# Altium schematic sheet EWB-STM32H7B0-750VB+RGB V3.0.SchDoc
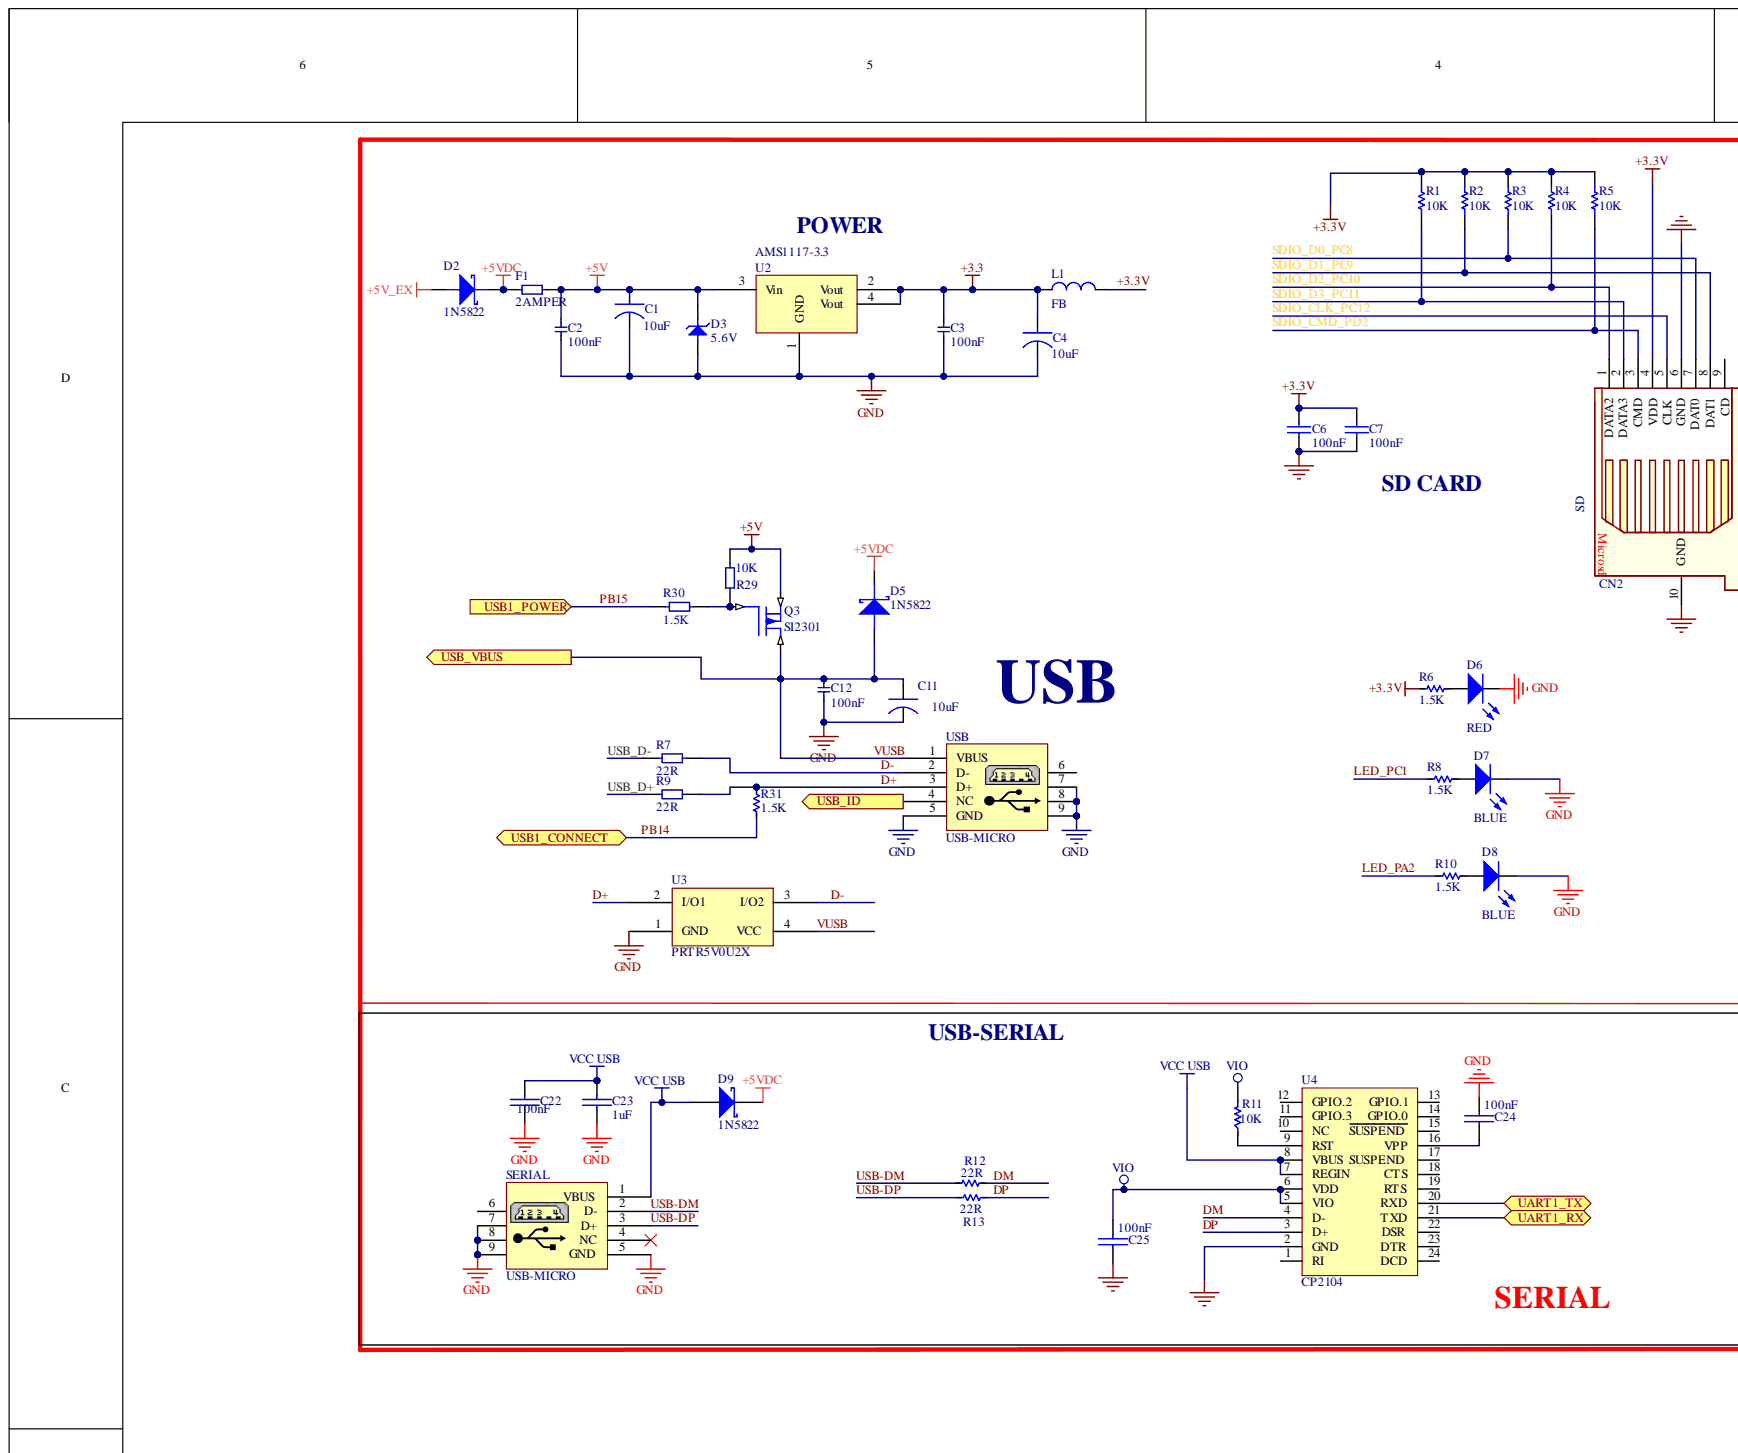
[diagram p0: EWB-STM32H7B0-750VB+RGB V3.0.SchDoc, top left]
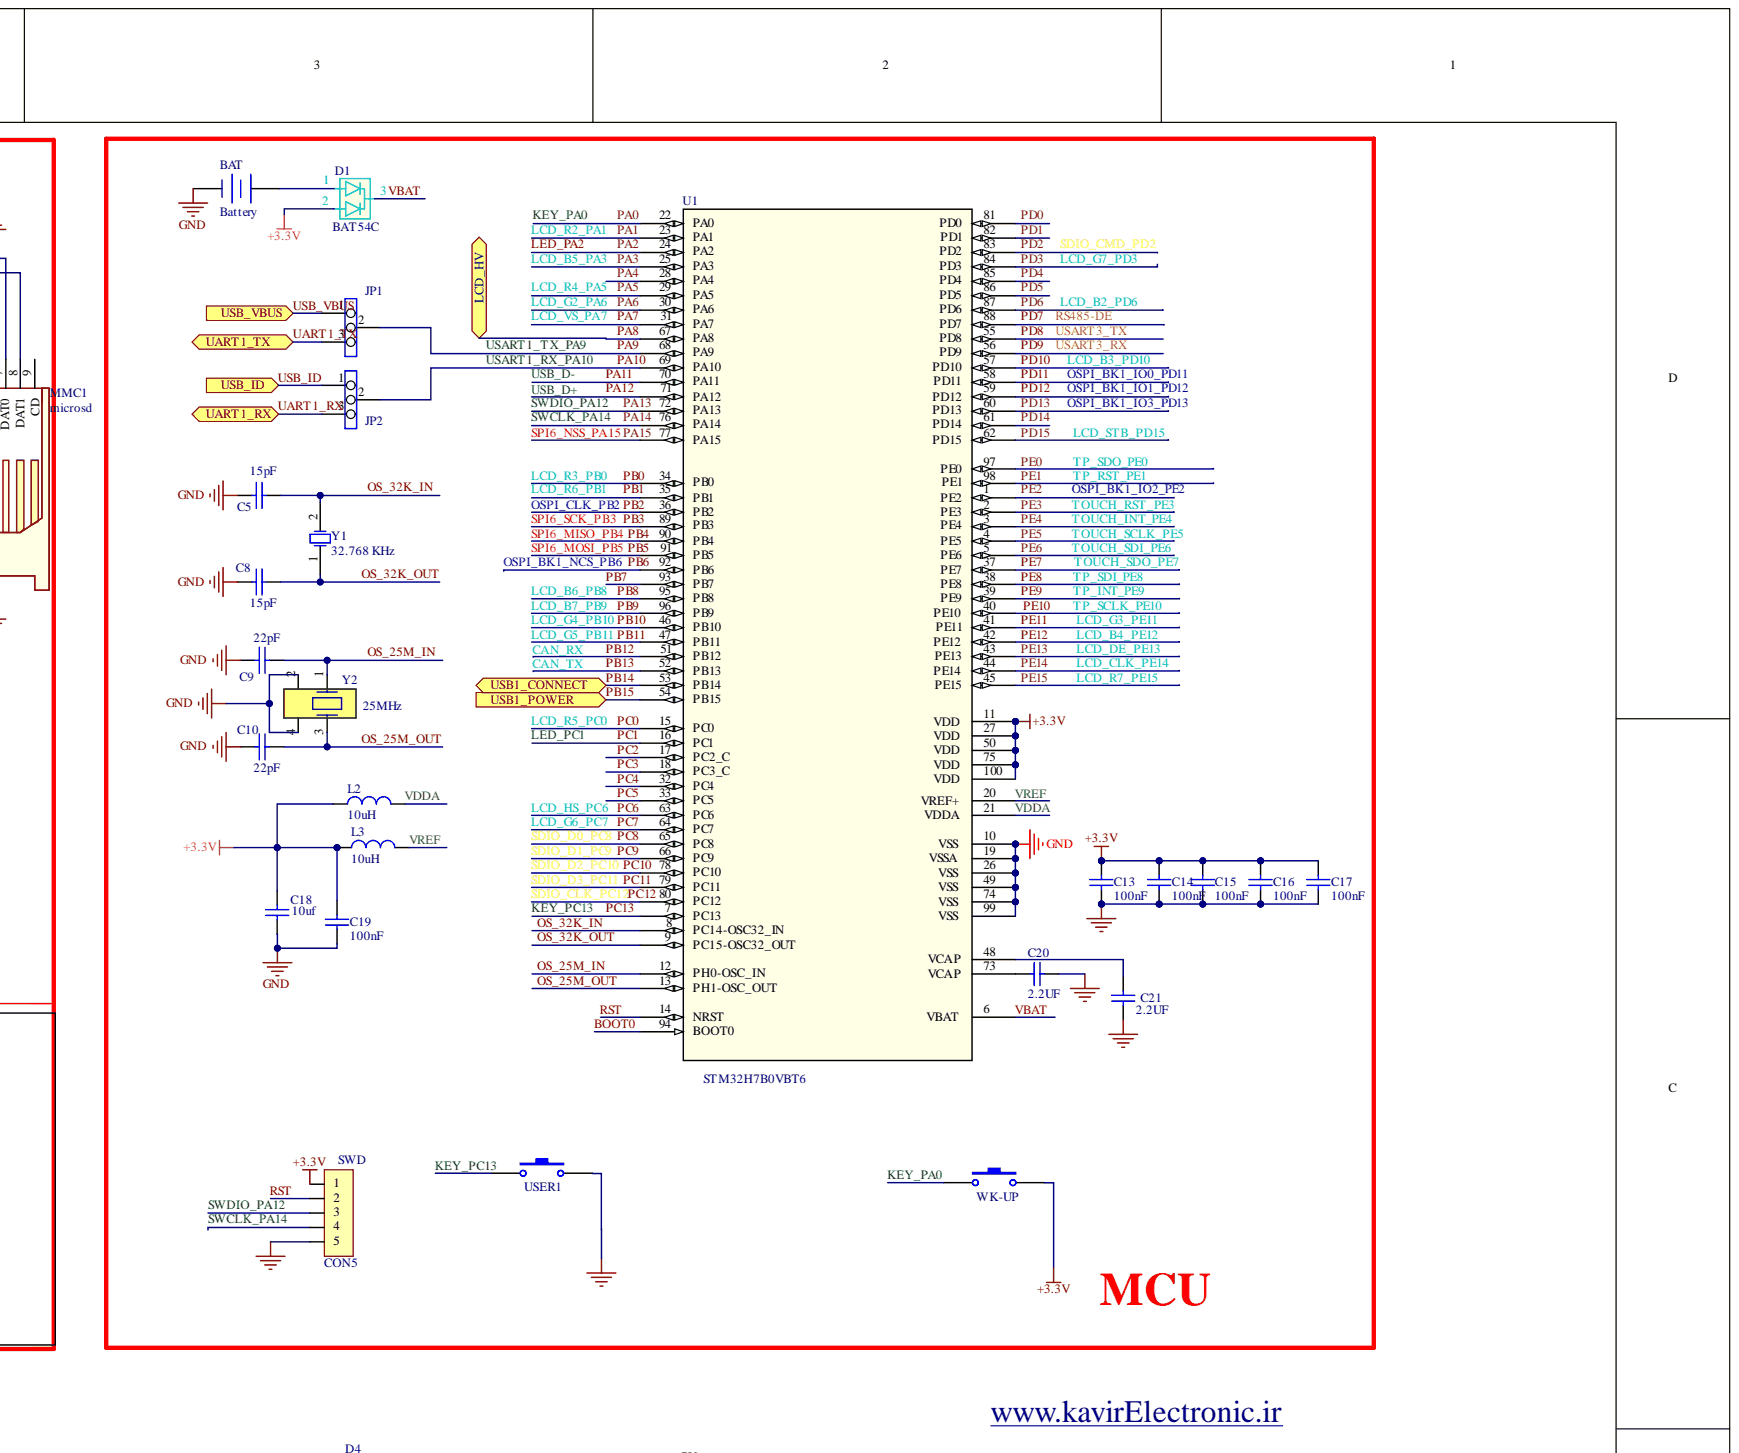
[diagram p1: EWB-STM32H7B0-750VB+RGB V3.0.SchDoc, top right]
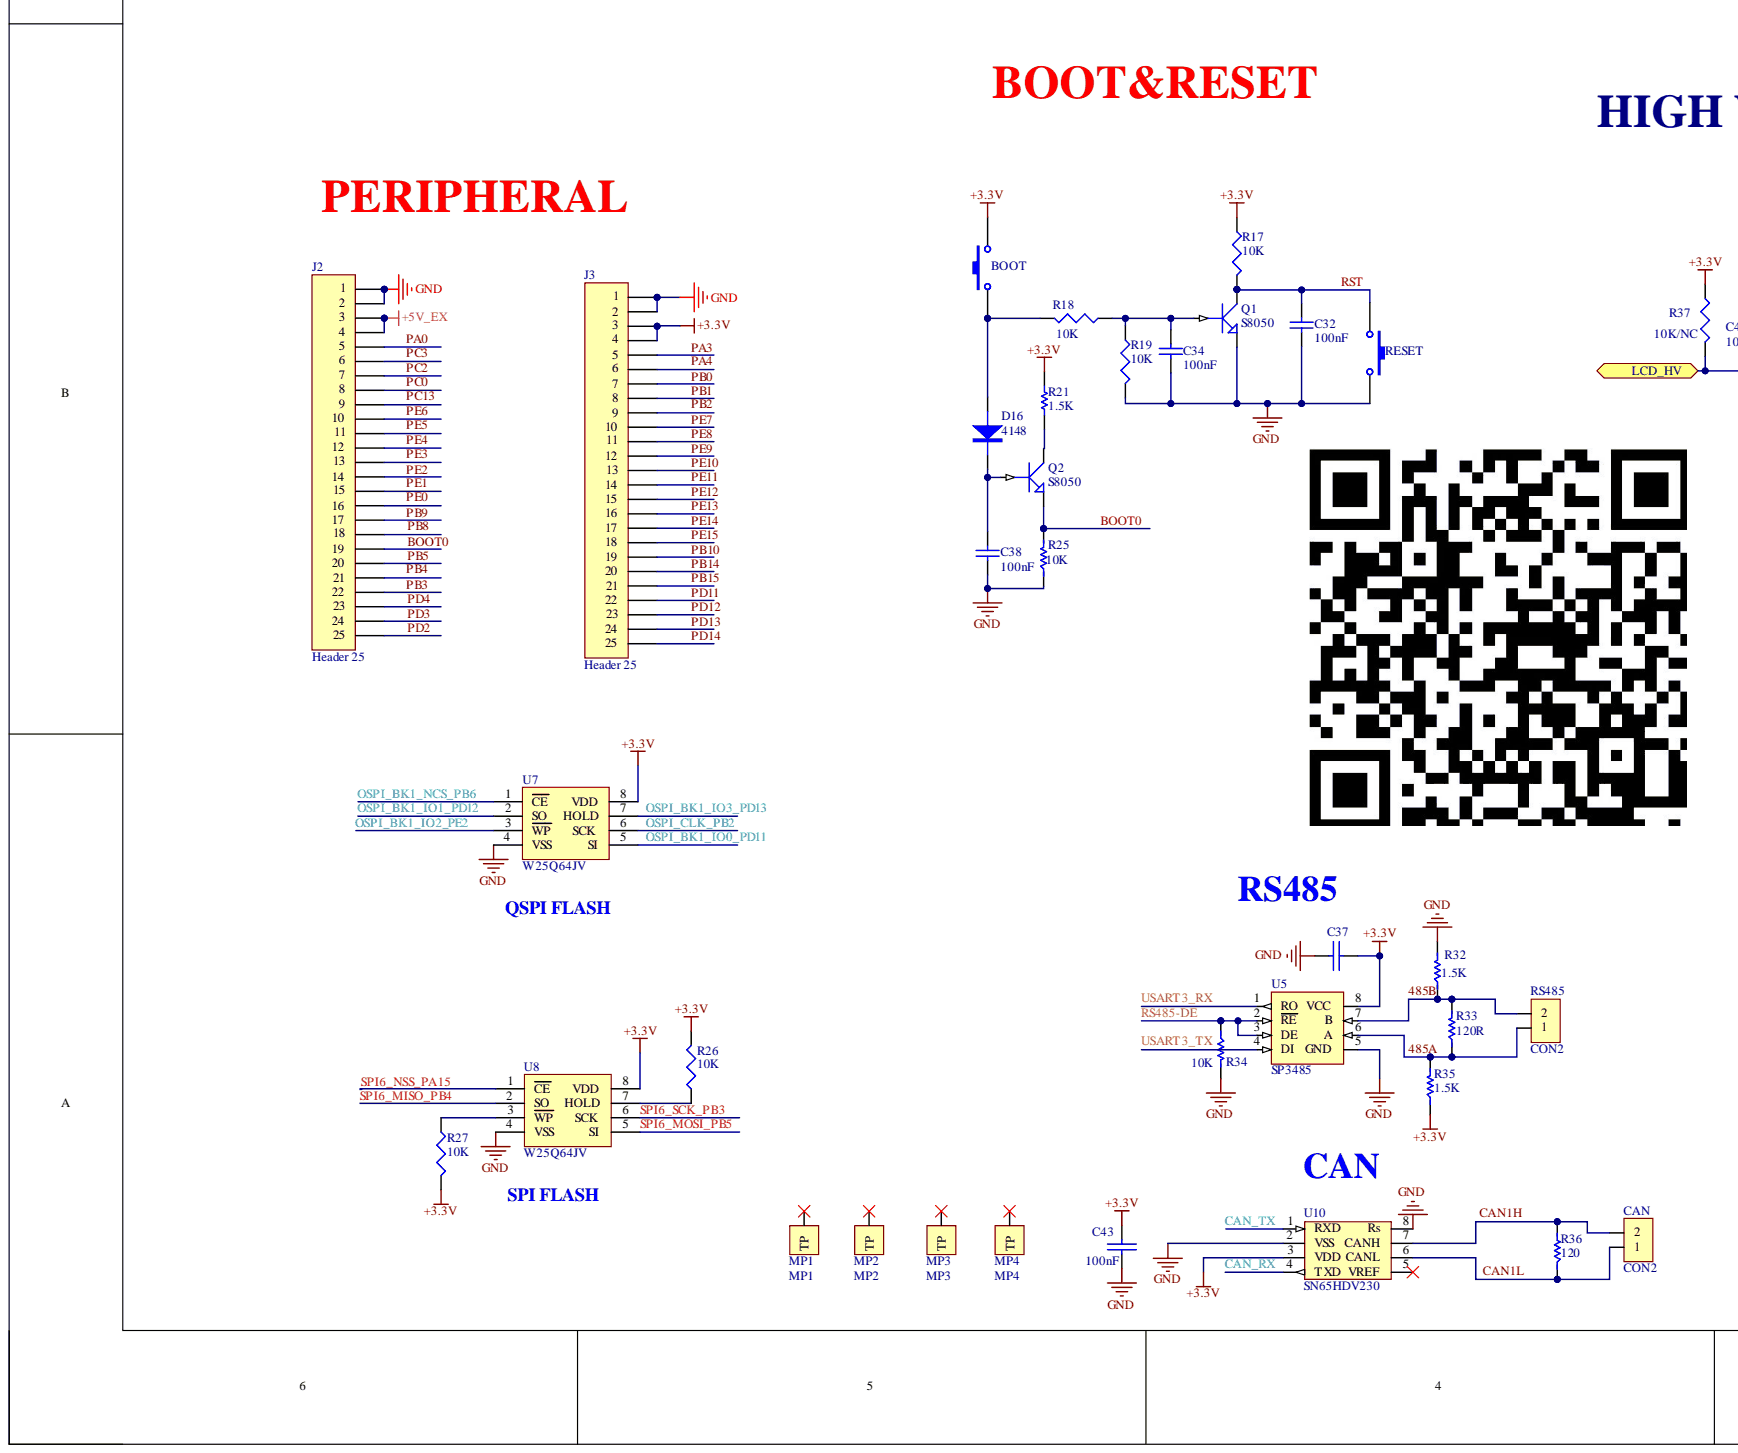
[diagram p2: EWB-STM32H7B0-750VB+RGB V3.0.SchDoc, bottom left]
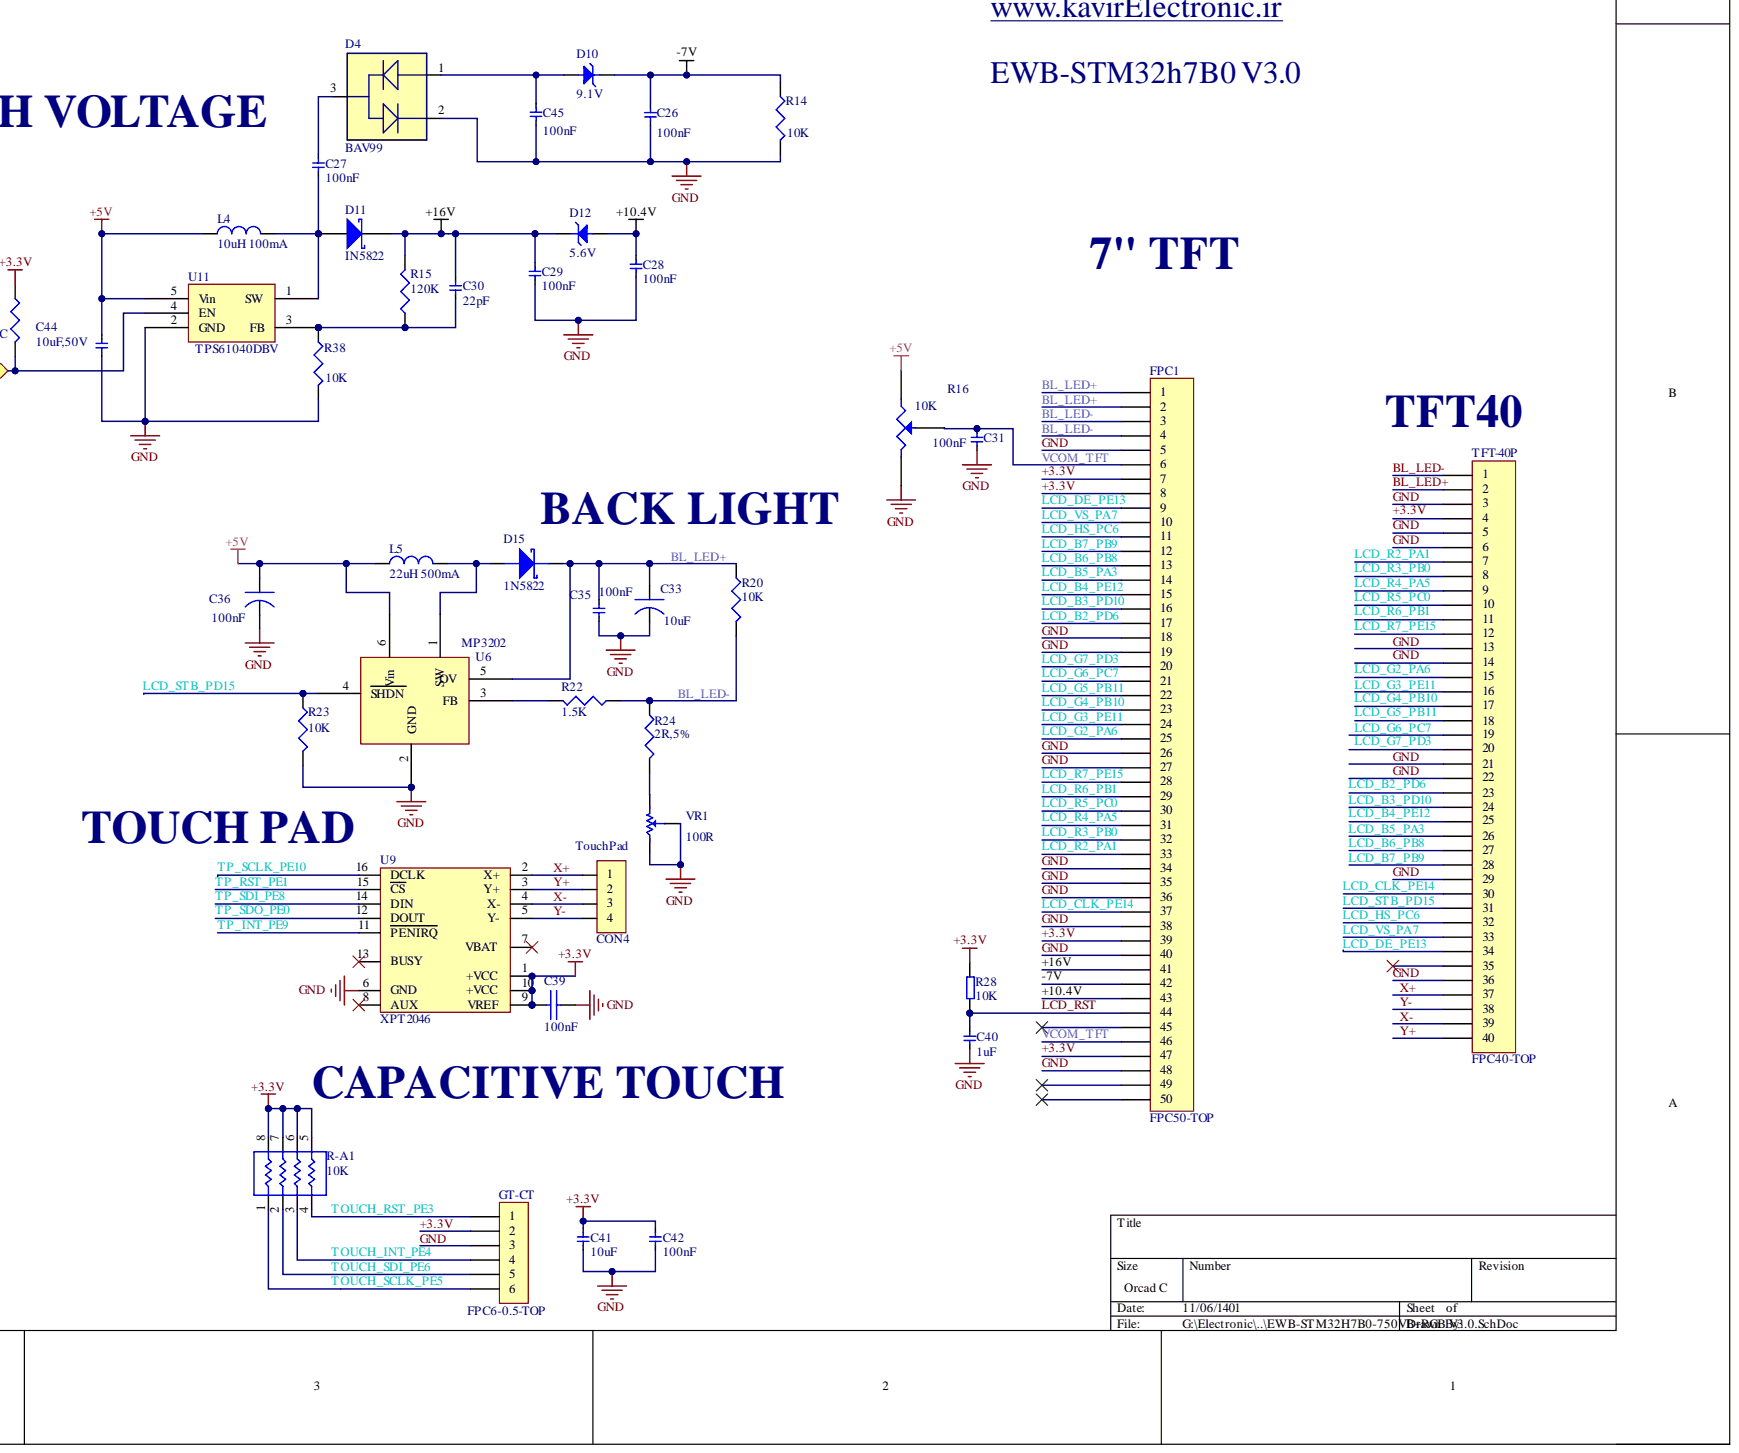
[diagram p3: EWB-STM32H7B0-750VB+RGB V3.0.SchDoc, bottom right]

## Parts
BAT  Battery  [Battery socket SMD]
BOOT  Tact-5*3  [Tact-5*3]  (value not drawn)
C1  10uF  [C0603]
C2  100nF  [C0603]
C3  100nF  [C0603]
C4  10uF  [C0603]
C5  15pF  [C0603]
C6  100nF  [C0603]
C7  100nF  [C0603]
C8  15pF  [C0603]
C9  22pF  [C0603]
C10  22pF  [C0603]
C11  10uF  [C0603]
C12  100nF  [C0603]
C13  100nF  [C0603]
C14  100nF  [C0603]
C15  100nF  [C0603]
C16  100nF  [C0603]
C17  100nF  [C0603]
C18  10uf  [C0603]
C19  100nF  [C0603]
C20  2.2UF  [C0603]
C21  2.2UF  [C0603]
C22  100nF  [C0603]
C23  1uF  [C0603]
C24  100nF  [C0603]
C25  100nF  [C0603]
C26  100nF  [0603C]
C27  100nF  [0603C]
C28  100nF  [0603C]
C29  100nF  [0603C]
C30  22pF  [0603C]
C31  100nF  [C0603]
C32  100nF  [C0603]
C33  10uF  [1206]
C34  100nF  [C0603]
C35  100nF  [C0603]
C36  100nF  [C0603]
C37  100nF  [0603C]  (value not drawn)
C38  100nF  [C0603]
C39  100nF  [C0603]
C40  1uF  [C0603]
C41  10uF  [C0603]
C42  100nF  [C0603]
C43  100nF  [0603C]
C44  10uF,50V  [1206]
C45  100nF  [0603C]
CAN  CON2  [SIP2]
D1  BAT54C  [SOT-23]
D2  1N5822  [SMA]
D3  5.6V  [MELF-D]
D4  BAV99  [SOT-23]
D5  1N5822  [SMA]
D6  RED  [LED0603]
D7  BLUE  [LED0603]
D8  BLUE  [LED0603]
D9  1N5822  [SMA]
D10  9.1V  [MELF-D]
D11  IN5822  [SMA]
D12  5.6V  [MELF-D]
D15  1N5822  [SMA]
D16  4148  [MELF-D]
F1  2AMPER  [0805R]
FPC1  FPC50-TOP  [FPC50]
GT-CT  FPC6-0.5-TOP  [FPC 6 Pin 0.5mm]
J2  Header 25  [HDR1X25]
J3  Header 25  [HDR1X25]
JP1  HDR1*3  [SIP3]  (value not drawn)
JP2  HDR1*3  [SIP3]  (value not drawn)
L1  FB  [L0603]
L2  10uH  [L0603]
L3  10uH  [L0603]
L4  10uH 100mA  [1812]
L5  22uH 500mA  [L5X5]
MMC1  microsd  [MMC-SMD]
MP1  MP1  [Mark Point 4]
MP2  MP2  [Mark Point 4]
MP3  MP3  [Mark Point 4]
MP4  MP4  [Mark Point 4]
Q1  S8050  [SOT-23]
Q2  S8050  [SOT-23]
Q3  SI2301  [SOT-23]
R1  10K  [R0603]
R2  10K  [R0603]
R3  10K  [R0603]
R4  10K  [R0603]
R5  10K  [R0603]
R6  1.5K  [R0603]
R7  22R  [R0603]
R8  1.5K  [R0603]
R9  22R  [R0603]
R10  1.5K  [R0603]
R11  10K  [R0603]
R12  22R  [R0603]
R13  22R  [R0603]
R14  10K  [R0603]
R15  120K  [R0603]
R16  10K  [MU-POT]
R17  10K  [R0603]
R18  10K  [R0603]
R19  10K  [R0603]
R20  10K  [R0603]
R21  1.5K  [R0603]
R22  1.5K  [R0603]
R23  10K  [R0603]
R24  2R,5%  [0805R]
R25  10K  [R0603]
R26  10K  [R0603]
R27  10K  [R0603]
R28  10K  [R0603]
R29  10K  [R0603]
R30  1.5K  [R0603]
R31  1.5K  [R0603]
R32  value 1.5K  [R0603]  (hidden comment: 1K)
R33  120R  [R0603]
R34  10K  [R0603]
R35  value 1.5K  [R0603]  (hidden comment: 1K)
R36  120  [0805R]
R37  10K/NC  [R0603]
R38  10K  [R0603]
R-A1  10K  [SOP8]
RESET  Tact-5*3  [Tact-5*3]  (value not drawn)
RS485  CON2  [SIP2]
SERIAL  USB-MICRO  [USB-MICRO]
SWD  CON5  [SIP5]
TFT-40P  FPC40-TOP  [FPC40]
TouchPad  CON4  [FFC/SM1.0-2H4]
U1  STM32H7B0VBT6  [TQFP100]
U2  AMS1117-3.3  [SOT-223]
U3  PRTR5V0U2X  [SOT143B]
U4  CP2104  [QFN-24]
U5  SP3485  [SO-8]
U6  MP3202  [TSOT23-6]
U7  W25Q64JV  [SO-8-W]
U8  W25Q64JV  [SO-8-W]
U9  XPT2046  [SSOP-16]
U10  SN65HDV230  [SO-8]
U11  TPS61040DBV  [SOT23-5]
USB  USB-MICRO  [USB-MICRO]
USER1  Tact-5*3  [Tact-5*3]  (value not drawn)
VR1  100R  [MU-POT]
WK-UP  Tact-5*3  [Tact-5*3]  (value not drawn)
Y1  32.768 KHz  [SMD-RTC-FC135]
Y2  25MHz  [Xtal 3.2*2.5 mm]

## Ports
LCD_HV, UART1_RX, UART1_TX, USB1_CONNECT, USB1_POWER, USB_ID, USB_VBUS

## Nets
+3.3: C3.2 C4.1 L1.1 U2.2(Vout) U2.4(Vout)
+5V: C1.1 C2.2 C36.1 C44.1 D3.K F1.1 L4.1 L5.1 Q3.2 R16.1 R29.2 U2.3(Vin) U6.6(Vin) U11.5(Vin)
+5V_EX: D2.A J2.3 J2.4
+5VDC: D2.K D5.K D9.K F1.2
VCC USB: C22.1 C23.1 D9.A SERIAL.1(VBUS) U4.7(REGIN) U4.8(VBUS)
VIO: C25.2 R11.2 U4.5(VIO) U4.6(VDD)
485A: R33.1 R35.2 RS485.1 U5.6(A)
485B: R32.1 R33.2 RS485.2 U5.7(B)
+3.3V: BOOT.1 C6.1 C7.1 C13.1 C14.1 C15.1 C16.1 C17.1 C18.1 C19.1 C37.2 C39.1 C41.2 C42.2 C43.2 D1.2 FPC1.7 FPC1.8 FPC1.39 FPC1.47 GT-CT.2 J3.3 J3.4 L1.2 L2.1 L3.1 MMC1.4(VDD) R1.1 R2.1 R3.1 R4.1 R5.1 R6.1 R17.1 R21.2 R26.2 R27.2 R28.2 R35.1 R37.1 R-A1.5 R-A1.6 R-A1.7 R-A1.8 SWD.1 TFT-40P.4 U1.11(VDD) U1.27(VDD) U1.50(VDD) U1.75(VDD) U1.100(VDD) U5.8(VCC) U7.8(VDD) U8.8(VDD) U9.1(+VCC) U9.9(VREF) U9.10(+VCC) U10.3(VDD) WK-UP.1
+10.4V: C28.1 D12.A FPC1.43
+16V: C29.1 C30.1 D11.K D12.K FPC1.41 R15.2
-7V: C26.1 D10.K FPC1.42 R14.1
BL_LED+: C33.1 C35.1 D15.K FPC1.1 FPC1.2 R20.1 TFT-40P.2 U6.5(OV)
BL_LED-: FPC1.3 FPC1.4 R20.2 R22.1 R24.1 TFT-40P.1
BOOT0: J2.19 Q2.2 R25.2 U1.94(BOOT0)
CAN1H: CAN.2 R36.2 U10.7(CANH)
CAN1L: CAN.1 R36.1 U10.6(CANL)
CAN_RX: U1.51(PB12) U10.4(T_XD)
CAN_TX: U1.52(PB13) U10.1(RXD)
D+: R9.1 R31.2 U3.2(I/O1) USB.3(D+)
D-: R7.1 U3.3(I/O2) USB.2(D-)
DM: R12.1 U4.4(D-)
DP: R13.1 U4.3(D+)
GND: BAT.2 C1.2 C2.1 C3.1 C4.2 C5.2 C6.2 C7.2 C8.2 C9.2 C10.2 C11.2 C12.2 C13.2 C14.2 C15.2 C16.2 C17.2 C18.2 C19.2 C20.2 C21.1 C22.2 C23.2 C24.2 C25.1 C26.2 C28.2 C29.2 C31.2 C32.2 C33.2 C34.2 C35.2 C36.2 C37.1 C38.2 C39.2 C40.1 C41.1 C42.1 C43.1 C44.2 C45.2 D3.A D4.2 D6.2 D7.2 D8.2 FPC1.5 FPC1.18 FPC1.19 FPC1.26 FPC1.27 FPC1.34 FPC1.35 FPC1.36 FPC1.38 FPC1.40 FPC1.48 GT-CT.3 J2.1 J2.2 J3.1 J3.2 MMC1.6(GND) MMC1.10(GND) Q1.2 R14.2 R16.3 R19.2 R23.2 R25.1 R32.2 R34.2 R38.2 RESET.1 SERIAL.5(GND) SERIAL.7 SERIAL.8 SERIAL.9 SWD.5 TFT-40P.3 TFT-40P.5 TFT-40P.6 TFT-40P.13 TFT-40P.14 TFT-40P.21 TFT-40P.22 TFT-40P.29 TFT-40P.36 U1.10(VSS) U1.19(VSSA) U1.26(VSS) U1.49(VSS) U1.74(VSS) U1.99(VSS) U2.1(GND) U3.1(GND) U4.2(GND) U5.5(GND) U6.2 U7.4(VSS) U8.4(VSS) U9.6(GND) U10.2(VSS) U10.8(Rs) U11.2(GND) USB.5(GND) USB.7 USB.8 USB.9 USER1.1 VR1.1 VR1.2 Y2.2 Y2.4
KEY_PA0: J2.5 U1.22(PA0) WK-UP.2
KEY_PC13: J2.9 U1.7(PC13) USER1.2
LCD_B2_PD6: FPC1.17 TFT-40P.23 U1.87(PD6)
LCD_B3_PD10: FPC1.16 TFT-40P.24 U1.57(PD10)
LCD_B4_PE12: FPC1.15 J3.15 TFT-40P.25 U1.42(PE12)
LCD_B5_PA3: FPC1.14 J3.5 TFT-40P.26 U1.25(PA3)
LCD_B6_PB8: FPC1.13 J2.18 TFT-40P.27 U1.95(PB8)
LCD_B7_PB9: FPC1.12 J2.17 TFT-40P.28 U1.96(PB9)
LCD_CLK_PE14: FPC1.37 J3.17 TFT-40P.30 U1.44(PE14)
LCD_DE_PE13: FPC1.9 J3.16 TFT-40P.34 U1.43(PE13)
LCD_G2_PA6: FPC1.25 TFT-40P.15 U1.30(PA6)
LCD_G3_PE11: FPC1.24 J3.14 TFT-40P.16 U1.41(PE11)
LCD_G4_PB10: FPC1.23 J3.19 TFT-40P.17 U1.46(PB10)
LCD_G5_PB11: FPC1.22 TFT-40P.18 U1.47(PB11)
LCD_G6_PC7: FPC1.21 TFT-40P.19 U1.64(PC7)
LCD_G7_PD3: FPC1.20 J2.24 TFT-40P.20 U1.84(PD3)
LCD_HS_PC6: FPC1.11 TFT-40P.32 U1.63(PC6)
LCD_R2_PA1: FPC1.33 TFT-40P.7 U1.23(PA1)
LCD_R3_PB0: FPC1.32 J3.7 TFT-40P.8 U1.34(PB0)
LCD_R4_PA5: FPC1.31 TFT-40P.9 U1.29(PA5)
LCD_R5_PC0: FPC1.30 J2.8 TFT-40P.10 U1.15(PC0)
LCD_R6_PB1: FPC1.29 J3.8 TFT-40P.11 U1.35(PB1)
LCD_R7_PE15: FPC1.28 J3.18 TFT-40P.12 U1.45(PE15)
LCD_RST: C40.2 FPC1.44 R28.1
LCD_STB_PD15: R23.1 TFT-40P.31 U1.62(PD15) U6.4(SHDN)
LCD_VS_PA7: FPC1.10 TFT-40P.33 U1.31(PA7)
LED_PA2: R10.1 U1.24(PA2)
LED_PC1: R8.1 U1.16(PC1)
OS_25M_IN: C9.1 U1.12(PH0-OSC_IN) Y2.1
OS_25M_OUT: C10.1 U1.13(PH1-OSC_OUT) Y2.3
OS_32K_IN: C5.1 U1.8(PC14-OSC32_IN) Y1.2
OS_32K_OUT: C8.1 U1.9(PC15-OSC32_OUT) Y1.1
OSPI_BK1_IO0_PD11: J3.22 U1.58(PD11) U7.5(SI)
OSPI_BK1_IO1_PD12: J3.23 U1.59(PD12) U7.2(SO)
OSPI_BK1_IO2_PE2: J2.14 U1.1(PE2) U7.3(WP)
OSPI_BK1_IO3_PD13: J3.24 U1.60(PD13) U7.7(HOLD)
OSPI_BK1_NCS_PB6: U1.92(PB6) U7.1(CE)
OSPI_CLK_PB2: J3.9 U1.36(PB2) U7.6(SCK)
PA4: J3.6 U1.28(PA4)
PA8: R37.2 U1.67(PA8) U11.4(EN) ; port LCD_HV
PA9: JP1.2 U1.68(PA9)
PA10: JP2.2 U1.69(PA10)
PA11: R7.2 U1.70(PA11)
PA12: R9.2 U1.71(PA12)
PA13: SWD.3 U1.72(PA13)
PA14: SWD.4 U1.76(PA14)
PA15: U1.77(PA15) U8.1(CE)
PB3: J2.22 U1.89(PB3) U8.6(SCK)
PB4: J2.21 U1.90(PB4) U8.2(SO)
PB5: J2.20 U1.91(PB5) U8.5(SI)
PB7: U1.93(PB7)
PB14: J3.20 R31.1 U1.53(PB14) ; port USB1_CONNECT
PB15: J3.21 R30.2 U1.54(PB15) ; port USB1_POWER
PC2: J2.7 U1.17(PC2_C)
PC3: J2.6 U1.18(PC3_C)
PC4: U1.32(PC4)
PC5: U1.33(PC5)
PC8: MMC1.7(DAT0) R3.2 U1.65(PC8)
PC9: MMC1.8(DAT1) R2.2 U1.66(PC9)
PC10: MMC1.1(DATA2) R4.2 U1.78(PC10)
PC11: MMC1.2(DATA3) R1.2 U1.79(PC11)
PC12: MMC1.5(CLK) U1.80(PC12)
PD0: U1.81(PD0)
PD1: U1.82(PD1)
PD2: J2.25 MMC1.3(CMD) R5.2 U1.83(PD2)
PD4: J2.23 U1.85(PD4)
PD5: U1.86(PD5)
PD7: R34.1 U1.88(PD7) U5.2(RE) U5.3(DE)
PD8: U1.55(PD8) U5.4(DI)
PD9: U1.56(PD9) U5.1(RO)
PD14: J3.25 U1.61(PD14)
PE0: J2.16 U1.97(PE0) U9.12(DOUT)
PE1: J2.15 U1.98(PE1) U9.15(CS)
PE3: GT-CT.1 J2.13 R-A1.4 U1.2(PE3)
PE4: GT-CT.4 J2.12 R-A1.3 U1.3(PE4)
PE5: GT-CT.6 J2.11 R-A1.1 U1.4(PE5)
PE6: GT-CT.5 J2.10 R-A1.2 U1.5(PE6)
PE7: J3.10 U1.37(PE7)
PE8: J3.11 U1.38(PE8) U9.14(DIN)
PE9: J3.12 U1.39(PE9) U9.11(PENIRQ)
PE10: J3.13 U1.40(PE10) U9.16(DCLK)
RST: C32.1 Q1.3 R17.2 RESET.2 SWD.2 U1.14(NRST)
UART1_RX: JP2.3 U4.21(T_XD) ; port UART1_RX
UART1_TX: JP1.3 U4.20(RXD) ; port UART1_TX
USB-DM: R12.2 SERIAL.2(D-)
USB-DP: R13.2 SERIAL.3(D+)
USB_ID: JP2.1 USB.4(NC) ; port USB_ID
USB_VBUS: C11.1 C12.1 D5.A JP1.1 Q3.3 U3.4(VCC) USB.1(VBUS) ; port USB_VBUS
VBAT: D1.3 U1.6(VBAT)
VCOM_TFT: C31.1 FPC1.6 FPC1.46 R16.2
VDDA: L2.2 U1.21(VDDA)
VREF: L3.2 U1.20(VREF+)
X+: TFT-40P.37 TouchPad.1 U9.2(X+)
X-: TFT-40P.39 TouchPad.3 U9.4(X-)
Y+: TFT-40P.40 TouchPad.2 U9.3(Y+)
Y-: TFT-40P.38 TouchPad.4 U9.5(Y-)
NetBAT_1: BAT.1 D1.1
NetBOOT_2: BOOT.2 D16.A R18.2
NetC20_1: C20.1 U1.73(VCAP)
NetC21_2: C21.2 U1.48(VCAP)
NetC24_1: C24.1 U4.16(VPP)
NetC27_1: C27.1 D4.3
NetC27_2: C27.2 D11.A L4.2 U11.1(SW)
NetC30_2: C30.2 R15.1 R38.1 U11.3(FB)
NetC34_1: C34.1 Q1.1 R18.1 R19.1
NetC38_1: C38.1 D16.K Q2.1
NetC45_1: C45.1 D4.1 D10.A
NetD6_1: D6.1 R6.2
NetD7_1: D7.1 R8.2
NetD8_1: D8.1 R10.2
NetD15_A: D15.A L5.2 U6.1(SW)
NetQ2_3: Q2.3 R21.1
NetQ3_1: Q3.1 R29.1 R30.1
NetR11_1: R11.1 U4.9(RST)
NetR22_2: R22.2 U6.3(FB)
NetR24_2: R24.2 VR1.3
NetR26_1: R26.1 U8.7(HOLD)
NetR27_1: R27.1 U8.3(WP)

Not connected: FPC1 45 49 50; MMC1 9(CD); MP1 1; MP2 1; MP3 1; MP4 1; SERIAL 4(NC) 6; TFT-40P 35; U4 1(RI) 10(NC) 11(GPIO.3) 12(GPIO.2) 13(GPIO.1) 14(GPIO.0) 15(SUSPEND) 17(SUSPEND) 18(CT_S) 19(RT_S) 22(DSR) 23(DTR) 24(DCD); U9 7(VBAT) 8(AUX) 13(BUSY); U10 5(VREF); USB 6

## Library data of the parts
BAT = Battery: Multicell Battery -1220; Component Kind: Standard; LatestRevisionDate: 17-Jul-2002; LatestRevisionNote: Re-released for DXP Platform.; Library Name: Miscellaneous Devices.IntLib; PackageDescription: Battery; 2 Leads; PackageReference: BAT-2; Published: 24-Mar-1999; Publisher: Altium Limited
BOOT RESET USER1 WK-UP = Switch PB: Push Button Switch; Component Kind: Standard; Library Name: My Library.IntLib
C1 C4 C11 C33 C36 = CAP_EL: CAPACITOR; Component Kind: Standard
  C1 C4 C11: Library Name: LIB_SCH.lib
C2 C3 C12 C26-C31 C35 C40-C42 C44 C45 = CAP1: CAPACITOR; Component Kind: Standard
  C26-C31 C35 C44 C45: Library Name: LIB_SCH.lib
C5-C10 C13-C17 C19 C32 C34 C38 = CAP: Capacitor - 603; Component Kind: Standard
  C6 C7 C13-C17 C19 C32 C34 C38: Library Name: Miscellaneous Devices.IntLib; Published: 8-Jun-2000; Revision: July-2002: Re-released for DXP Platform.; Signal Integrity: Cap; Simulation: CAP; Value: 100nF; Publisher: Altium Limited
C18 = c: Capacitor - 603; Component Kind: Standard; Library Name: INTEGRATED_LIBRARY1.IntLib
C20 C21 = CAP: Capacitor - 603; Value: 100nF; Component Kind: Standard; Library Name: Miscellaneous Devices.IntLib; Published: 8-Jun-2000; Revision: July-2002: Re-released for DXP Platform.; Signal Integrity: Cap; Simulation: CAP; Publisher: Altium Limited
C22 C23 C37 C39 C43 = Cap-Bipolar: Bipolar Capacitor; Designer: Saeid Jorablo; Organazation: ControTec; Voltage: 100V; Adding Date: 1387/12/20; Component Kind: Standard; Library Name: My Library.IntLib
  C23: Value: 1uF
  C39 C43: Value: 100nF
C24 C25 = Cap-Bipolar: Capacitor - 603; Designer: Saeid Jorablo; Organazation: ControTec; Voltage: 100V; Adding Date: 1387/12/20; Component Kind: Standard; Library Name: My Library.IntLib; Value: 100nF
CAN RS485 = CON2: CONNECTOR; Component Kind: Standard; Library Name: LIB_SCH.lib
D2 D5 D15 = DIODE SCHOTTKY: Schottky Diode; Component Kind: Standard; Library Name: LIB_SCH.lib
D3 D10 D12 = ZENER1: ZENER DIODE; Component Kind: Standard; Library Name: LIB_SCH.lib
D4 U4 U7-U10 = Component_1: Component Kind: Standard
  D4: Library Name: BAV99.IntLib
  U4: Library Name: CP2104.IntLib
  U7 U8: Library Name: M25P10-A.IntLib
  U9: Library Name: ADS7846.IntLib
  U10: Library Name: MCP2551.IntLib
D6 = LED-2L: LED RED 0603; Component Kind: Standard; Library Name: INTEGRATED_LIBRARY1.IntLib
D7 D8 = LED-2L: LED BLUE 0603; Component Kind: Standard; Library Name: INTEGRATED_LIBRARY1.IntLib
D9 D11 = D Schottky: Schottky Diode; Code_JEDEC: DO-214-AA; Component Kind: Standard; LatestRevisionDate: 17-Jul-2002; LatestRevisionNote: Re-released for DXP Platform.; Library Name: Miscellaneous Devices.IntLib; PackageDescription: DO-214-AA/SMB; 2 C-Bend Leads; Body 5.3 x 3.6 mm, inc. leads (LxW); PackageReference: SMB; Published: 8-Jun-2000; Signal Integrity: D Schottky; Simulation: SKYDIODE; Publisher: Altium Limited
D16 = DIODE: Component Kind: Standard; Description: DIODE; Library Name: LIB_SCH.lib
F1 = RES 0805: Fuse; Component Kind: Standard; Library Name: RESISTOR 0805.IntLib
FPC1 = Header50: Component Kind: Standard
GT-CT = CON6: CONNECTOR; Component Kind: Standard; Library Name: LIB_SCH.lib
J2 J3 = Header 25: Header, 25-Pin; Component Kind: Standard; LatestRevisionDate: 17-Jul-2002; LatestRevisionNote: Re-released for DXP Platform.; Library Name: Miscellaneous Connectors.IntLib; Signal Integrity: Connector; Publisher: Altium Limited
JP1 JP2 = Jumper3: Component Kind: Standard; Library Name: STR910_SCHLIB.SCHLIB
L1-L5 = INDUCTOR: Component Kind: Standard; Library Name: LIB_SCH.lib
MP1-MP4 = TP: Component Kind: Standard; Library Name: LIB_SCH.lib
Q1 Q2 = NPNSMD: NPN Transistor; Component Kind: Standard
Q3 = P-Channel MOSFET: Component Kind: Standard; Description: P-Channel MOSFET; Library Name: LIB_SCH.lib
R1-R5 R21 = res1: Resistor-603; Component Kind: Standard; Library Name: Miscellaneous Devices.IntLib; Published: 8-Jun-2000; Revision: July-2002: Re-released for DXP Platform.; Publisher: Altium Limited
  R1-R5: Value: 10K
  R21: Value: 1.5K
R6 R8 R10 R12 R13 R31 = R: Resistor-603; Component Kind: Standard; Library Name: INTEGRATED_LIBRARY1.IntLib
R7 R9 R29 R30 = RES 0805: Component Kind: Standard; Library Name: RESISTOR 0805.IntLib
R11 R25 = Resistor: Resistor-603; Power: 1/8 W; Tolerance: 5%; Component Kind: Standard; Library Name: My Library.IntLib; Simulation: Model Name; Value: 10K
R14 R15 R20 R22-R24 R37 R38 = RES: RESISTOR; Component Kind: Standard; Library Name: LIB_SCH.lib
R16 = POT1: POT; Component Kind: Standard; Library Name: LIB_SCH.lib
R17-R19 = RES-SMD: Resistor SMD; Value: 10K 5% 0603(1608); Component Kind: Standard; Library Name: Utilit-Lib1.IntLib
R26 R27 = RES: Resistor-603; Component Kind: Standard; Library Name: LIB_SCH.lib
R28 = RES2: RESISTOR; Component Kind: Standard
R32-R36 = Resistor: Power: 1/8 W; Tolerance: 5%; Component Kind: Standard; Description: Resistor; Library Name: My Library.IntLib; Simulation: Model Name
  R33: Value: 120R
  R36: Value: 120
R-A1 = RESPACK4_2: RESISTOR ARRAY; Component Kind: Standard; Library Name: LIB_SCH.lib
SERIAL USB = USB mini: mini USB Connector; Component Kind: Standard; Library Name: My Library.IntLib
SWD = CON5: Header, 5-Pin 2.54mm; Component Kind: Standard; Library Name: LIB_SCH.lib
TFT-40P = Header40: Component Kind: Standard
TouchPad = CON4: CONNECTOR; Component Kind: Standard
U1 = STM32H7B0: Manufacturer_Part_Number: STM32H750VBT6; Built_By: EMA_Jessica; Vendor: STMicroelectronics; Component Kind: Standard; Library Name: LIB_SCH.lib; RefDes: RefDes; Type: DEV; Value: Value; Copyright: Copyright (C) 2018 Accelerated Designs. All rights reserved
U2 = AMS1117: 1A LOW DROPOUT VOLTAGE REGULATOR; Component Kind: Standard
U5 = ST485: RS485 TRANCCIVER; Component Kind: Standard; Library Name: LIB_SCH.lib
U6 = MP3202: High Current Boost  White LED Driver; Component Kind: Standard; Library Name: LIB_SCH.lib
U11 = TPS61040: Component Kind: Standard
VR1 = Resistor Variable: Variable Resistor; Company: ControTec; Designer: Saeid Jorablo; Adding Date: 137/12/22; Component Kind: Standard; Library Name: My Library.IntLib; Value: 100R
Y1 = XTAL: XTAL Crystal; Company: E.P.I.L; Value: 32.768 MHz; Component Kind: Standard; Design Date: 11/04/2011; Library Name: My Library.IntLib
Y2 = XTAL 4pin: Component Kind: Standard; Library Name: Xtal.IntLib
D1 = BAT54C: Component Kind: Standard; Library Name: INTEGRATED_LIBRARY1.IntLib
MMC1 = microsd: Component Kind: Standard; Library Name: kavirEshop.IntLib
U3 = PRTR5V0U2X: Component Kind: Standard
